# Altium schematic sheet iRTT_CP.SchDoc(iRTT_CP)
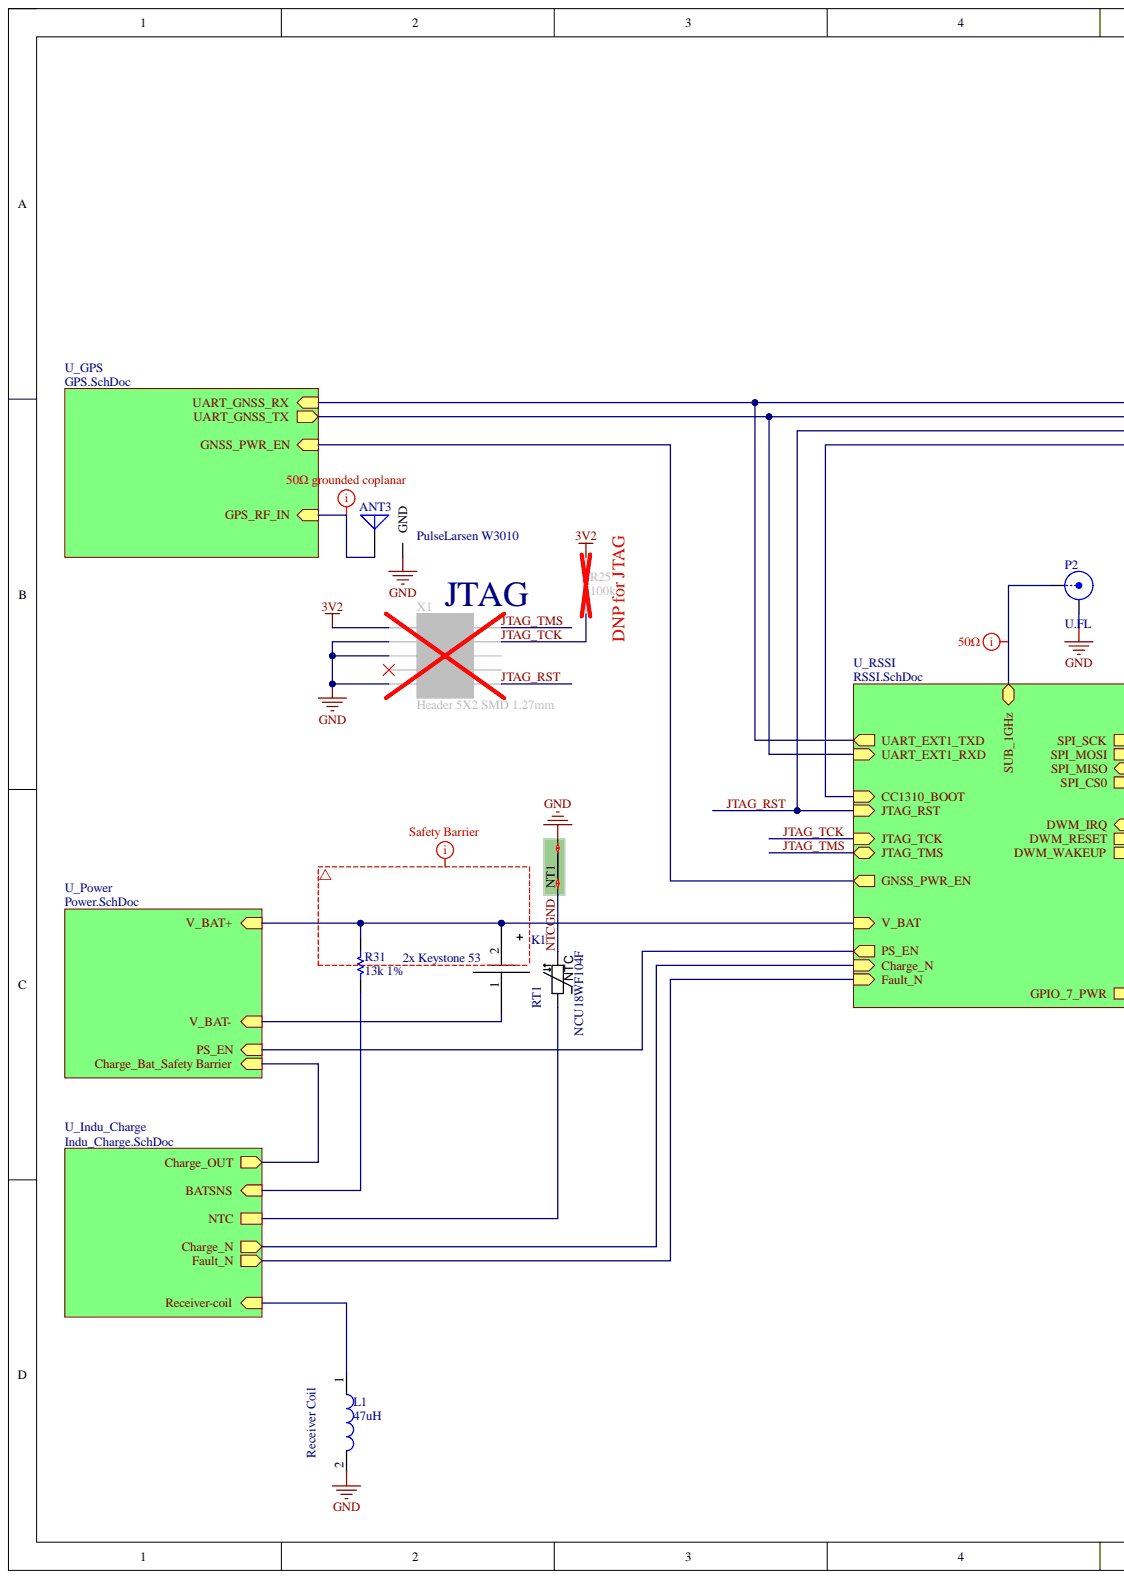
[diagram p0: iRTT_CP.SchDoc, left]
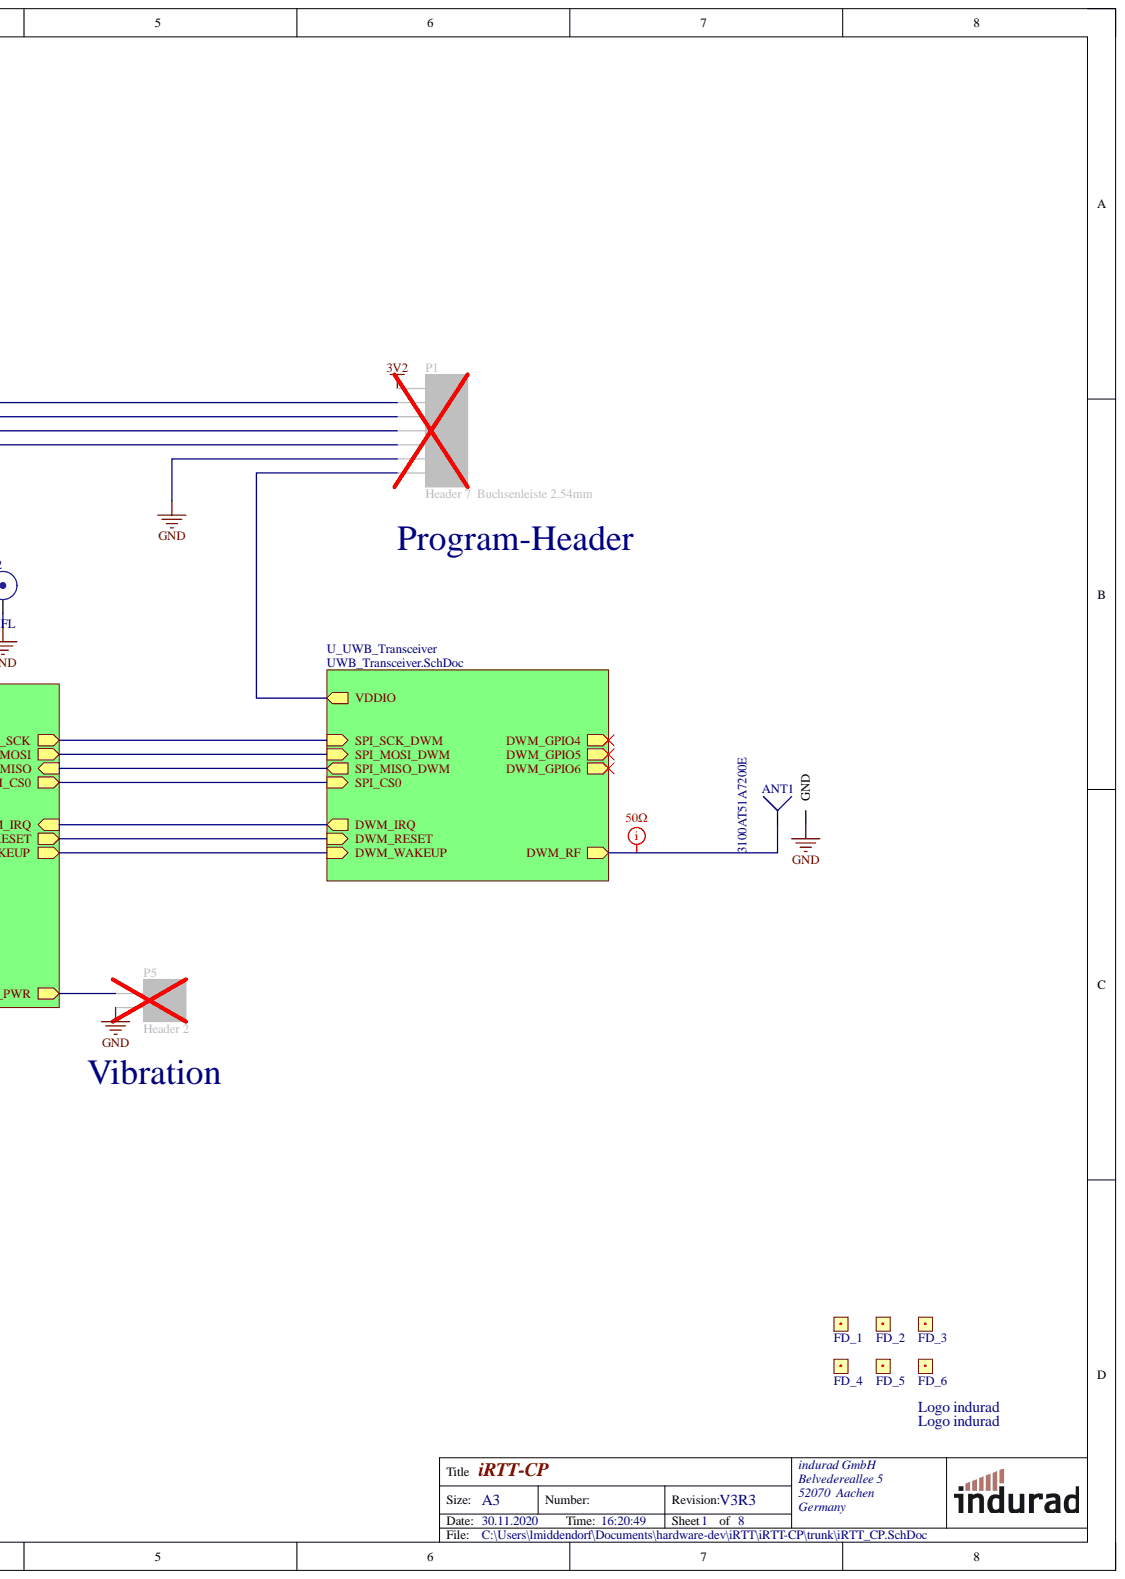
[diagram p1: iRTT_CP.SchDoc, right]

## Parts
ANT1  3100AT51A7200E  [UWB_Antenne_3100AT51A7200E]
ANT3  PulseLarsen W3010  [GNSS_Antenna_W3010]
FD_1  Fiducial  [BestueckerMarke_ohne_TopOverlay]  (value not drawn)
FD_2  Fiducial  [BestueckerMarke_ohne_TopOverlay]  (value not drawn)
FD_3  Fiducial  [BestueckerMarke_ohne_TopOverlay]  (value not drawn)
FD_4  Fiducial  [BestueckerMarke_ohne_TopOverlay]  (value not drawn)
FD_5  Fiducial  [BestueckerMarke_ohne_TopOverlay]  (value not drawn)
FD_6  Fiducial  [BestueckerMarke_ohne_TopOverlay]  (value not drawn)
i1  Logo_indurad  [LOGO_INDURAD_3mm_WO_TL]  (no BOM)
i2  Logo_indurad  [LOGO_INDURAD_3mm_WO_TL]  (no BOM)
K1  2x Keystone 53  [Battery Hoder AA Cell]
L1  47uH  Function: Receiver  [760308101303]
NT1  NT  [NET_TIE_0.15mm]
P1  Header 7  Buchsenleiste 2.54mm  [HDR1X7]
P2  U.FL  [U.FL Kupplung]
P5  Header 2  [HDR1X2]
R25  100k  [0603_N_(1608)]
R31  13k 1%  [0603_N_(1608)]
RT1  NCU18WF104F  [0603_N_(1608)]
X1  Header 5X2 SMD 1.27mm  [Header 5X2 SMD 1.27mm]

## Nets
3V2: P1.1 R25.2 X1.1
GND: ANT1.2 ANT3.2 L1.2 NT1.1 P1.6 P2.2 P5.1 X1.3 X1.5 X1.9
JTAG_RST: P1.4 X1.10
JTAG_TCK: R25.1 X1.4
JTAG_TMS: X1.2
NTCGND: NT1.0 RT1.2
NetANT1_1: ANT1.1
NetANT3_1: ANT3.1
NetK1_1: K1.1
NetK1_2: K1.2 R31.1
NetL1_1: L1.1
NetP1_2: P1.2
NetP1_3: P1.3
NetP1_5: P1.5
NetP1_7: P1.7
NetP2_1: P2.1
NetP5_2: P5.2
NetR31_2: R31.2
NetRT1_1: RT1.1
NetX1_6: X1.6
NetX1_7: X1.7
NetX1_8: X1.8
N00001:
N00002:
N00003:
N00004:
N00005:
N00006:
N00007:
N00008:
N00009:
N00010:
N00011:
N00012:
N00013:
N00014:
N00015:

## Library data of the parts
ANT1 = UWB Antenna: ANTENNA CHIP UWB 3.1 - 10.3 GHZ; Manufacturer: Johanson Technology Inc.; Frequency (Center/Band): 6.7GHz; Frequency Group: SHF (3 GHz ~ 4 GHz), SHF (f > 4 GHz); Frequency Range: 3.1GHz ~ 10.3GHz; Gain: 1.5dBi; Number of Bands: 1; Power - Max: 3W; Return Loss: -9.5dB; Antenna Type: Chip; Applications: -; Category: RF/IF and RFID; Component Kind: Standard; ComponentLink1Description: http://www.johansontechnology.com/datasheets/antennas/antenna_3100AT51A7200.pdf; ComponentLink1URL: http://www.johansontechnology.com/datasheets/antennas/antenna_3100AT51A7200.pdf; Features: -; Height (Max): 0.047" (1.20mm); Ingress Protection: -; Manufacturer Part Number: 3100AT51A7200E; Mounting Type: Surface Mount; Pricing 1: 1=2.49, 10=2.065, 25=1.9744, 50=1.9136, 100=1.7313, 250=1.4276, 500=1.2757 (EUR); RoHS: RoHS Compliant; Stock 1: 2506; Supplier 1: Digi-Key; Supplier Part Number 1: 712-1402-1-ND; Termination: Solder; VSWR: -; Library Name: Indurad.SchLib
ANT3 = GPS-GLONASS-Antenna: Pulse W3010 Antenna GPS/GLONASS/Beidou/Galileo; Manufacturer: PulseLarsen Antennas; Antenna Type: Chip; Frequency (Center/Band): 1.575GHz; Frequency Group: UHF (1 GHz ~ 2 GHz); Gain: 2.8dBi; Return Loss: -18dB; Applications: GPS; Category: RF/IF and RFID; ClassName: no_collision; Component Kind: Standard; ComponentLink1Description: http://productfinder.pulseeng.com/products/datasheets/W3010%20L192.pdf; ComponentLink1URL: http://productfinder.pulseeng.com/products/datasheets/W3010%20L192.pdf; Features: -; Frequency Range: -; Height (Max): 0.079" (2.00mm); Ingress Protection: -; Manufacturer Part Number: W3010; Mounting Type: Surface Mount; Packaging: Cut Tape (CT); Part Status: Active; Pricing 1: 1=1.47, 10=1.327, 25=1.1856, 100=1.0668, 250=0.94832, 500=0.82978 (EUR); RoHS: RoHS Compliant; Stock 1: 10143; Supplier 1: Digi-Key; Supplier Part Number 1: 553-1670-1-ND; Termination: Solder; VSWR: -; Library Name: Indurad.SchLib
i1 i2 = Logo_indurad: Logo von indurad GmbH; Library Name: InduradCommon.SchLib
K1 = Batteriehalter AA Zelle (Mignon): Manufacturer: Keystone Electronics; Manufacturer Part Number: 2x 53TR; Battery Cell Size: Multiple; Battery Type, Function: Cylindrical, Clip; EMS Note: 2 clips per BOM item; Number of Cells: 1; Style: Contact Clip; Battery Series: -; Category: Battery Products; ClassName: no_collision; Component Kind: Standard; ComponentLink1Description: http://www.keyelco.com/product-pdf.cfm?p=811; ComponentLink1URL: http://www.keyelco.com/product-pdf.cfm?p=811; Description: Batteriehalter AA Zelle (Mignon); Height Above Board: 0.569" (14.45mm); Mounting Type: PCB, Surface Mount; Operating Temperature: -; Pricing 1: 1=0.75, 10=0.662, 50=0.6352 (EUR); RoHS: RoHS Compliant; Stock 1: 11279; Supplier 1: Digi-Key; Supplier Part Number 1: 36-53CT-ND; Termination Style: SMD (SMT) Tab; Library Name: Indurad.SchLib
L1 = IND-WE-WE-WPCC-760308101303: WE-WPCC Wireless Power Charging Receiver Coil, Size Ø 26, 47uH; Manufacturer: Wurth Electronics Inc.; Current - Saturation: 3A; Current Rating: 1.5A; DC Resistance (DCR): 460 mOhm; Frequency - Self Resonant: 8MHz; Inductance: 47µH; Q @ Freq: 25 @ 125kHz; Tolerance: ±10%; Type: 1 Coil, 1 Layer; Category: Inductors, Coils, Chokes; ClassName: Thermalpad; Component Kind: Standard; ComponentLink1Description: Manufacturer; ComponentLink1URL: http://www.we-online.de; ComponentLink2Description: Datasheet; ComponentLink2URL: http://katalog.we-online.de/pbs/datasheet/760308101303.pdf; Manufacturer Part Number: 760308101303; Operating Temperature: -20°C ~ 105°C; Part Status: Active; PartNumber: 760308101303; Pricing 1: 1=10.21, 50=9.6492, 100=8.1651, 250=7.05188, 384=5.93776 (EUR); PublishedDate: July 2016; RoHS: RoHS Compliant; Size: Ø 26; Size / Dimension: 1.02" L x 1.02" W x 0.05" H (26.0mm x 26.0mm x 1.3mm); Stock 1: 1371; Supplier 1: Digi-Key; Supplier Part Number 1: 732-6207-ND; Value: 47uH; Library Name: Indurad.SchLib; Publisher: Wurth Elektronik
NT1 = NET-TIE: A net tie to connect different nets.; Component Kind: Net Tie; Library Name: InduradCommon.SchLib
P1 = Header 7: Header, 7-Pin; ClassName: no_collision; Component Kind: Standard; LatestRevisionDate: 17-Jul-2002; LatestRevisionNote: Re-released for DXP Platform.; Library Name: Miscellaneous Connectors.IntLib; Signal Integrity: Connector; Publisher: Altium Limited
P2 = U.FL KUPPLUNG: Manufacturer: HRS (HIROSE); Manufacturer Part Number: U.FL-R-SMT-1(10); Component Kind: Standard; ComponentLink1Description: http://www.farnell.com/datasheets/91524.pdf; ComponentLink1URL: http://www.farnell.com/datasheets/91524.pdf; Description: U.FL KUPPLUNG; LatestRevisionDate: 17-Jul-2002; LatestRevisionNote: Re-released for DXP Platform.; Pricing 1: 1=0,481, 10=0,436, 25=0,407, 50=0,365, 100=0,33 (EUR); RoHS: YES; Stock 1: 104388; Supplier 1: Farnell; Supplier Part Number 1: 1688077; Library Name: Indurad.SchLib; Publisher: Altium Limited
P5 = Header 2: Header, 2-Pin; ClassName: Thermalpad; Component Kind: Standard; LatestRevisionDate: 17-Jul-2002; LatestRevisionNote: Re-released for DXP Platform.; Library Name: Miscellaneous Connectors.IntLib; Signal Integrity: Connector; Publisher: Altium Limited
R25 R31 = Res: Resistor; Component Kind: Standard; Signal Integrity: Res; Simulation: RESISTOR; Library Name: Indurad.SchLib
  R25: Value: 100k
  R31: Value: 13k 1%
RT1 = NCU18WF104: NTC Thermistor 100kR 1% 4250K; Manufacturer: Murata; Manufacturer Part Number: NCU18WF104F6SRB; Case Code (Imperial): 0603; Case Code (Metric): 1608; Component Kind: Standard; ComponentLink2Description: Catalog; ComponentLink2URL: https://www.murata.com/~/media/webrenewal/support/library/catalog/products/thermistor/r03e.ashx?la=en-us&src-supplier=Digi-Key; ComponentLink3Description: Data Sheet; ComponentLink3URL: https://www.murata.com/~/media/webrenewal/products/thermistor/ntc/ncu/ncu18-s.ashx?la=en-gb; Library Name: RT_Thermistor.SchLib; Max Operating Temperature: 150°C; Min Operating Temperature: -40°C; REACH SVHC: No SVHC; RoHS: No; Supplier 1: Digi-Key; Supplier 2: Mouser; Supplier Part Number 1: 490-18150-1-ND; Supplier Part Number 2: 81-NCU18WF104F6SRB
X1 = Header 5X2 SMD 1.27mm: Header, 10-Pin, 1.27mm pitch; Manufacturer: Amphenol FCI; Manufacturer Part Number: 20021121-00010C4LF; Connector Type: Header, Unshrouded; Number of Positions: 10; Number of Positions Loaded: All; Pitch: 0.050" (1.27mm); Category: Connectors, Interconnects; ClassName: Thermalpad; Color: Black; Component Kind: Standard; ComponentLink1Description: http://portal.fciconnect.com/Comergent//fci/drawing/20021121.pdf; ComponentLink1URL: http://portal.fciconnect.com/Comergent//fci/drawing/20021121.pdf; Contact Finish: Gold; Contact Finish Thickness: 10µin (0.25µm); Contact Mating Length: 0.120" (3.05mm); Contact Type: Male Pin; Fastening Type: -; Features: -; Mounting Type: Surface Mount; Number of Rows: 2; Packaging: Cut Tape (CT); Part Status: Active; Pricing 1: 1=0.72, 10=0.633, 25=0.5956, 50=0.5706, 100=0.5459, 250=0.49628 (EUR); RoHS: RoHS Compliant; Row Spacing: 0.050" (1.27mm); Stock 1: 28631; Supplier 1: Digi-Key; Supplier Part Number 1: 609-3695-1-ND; Termination: Solder; Library Name: Indurad.SchLib
FD_1-FD_6 = Fiducial: Component Kind: Standard; Description: Fiducial; Library Name: Indurad.SchLib
